# Altium schematic sheet CustumBulun.Sch
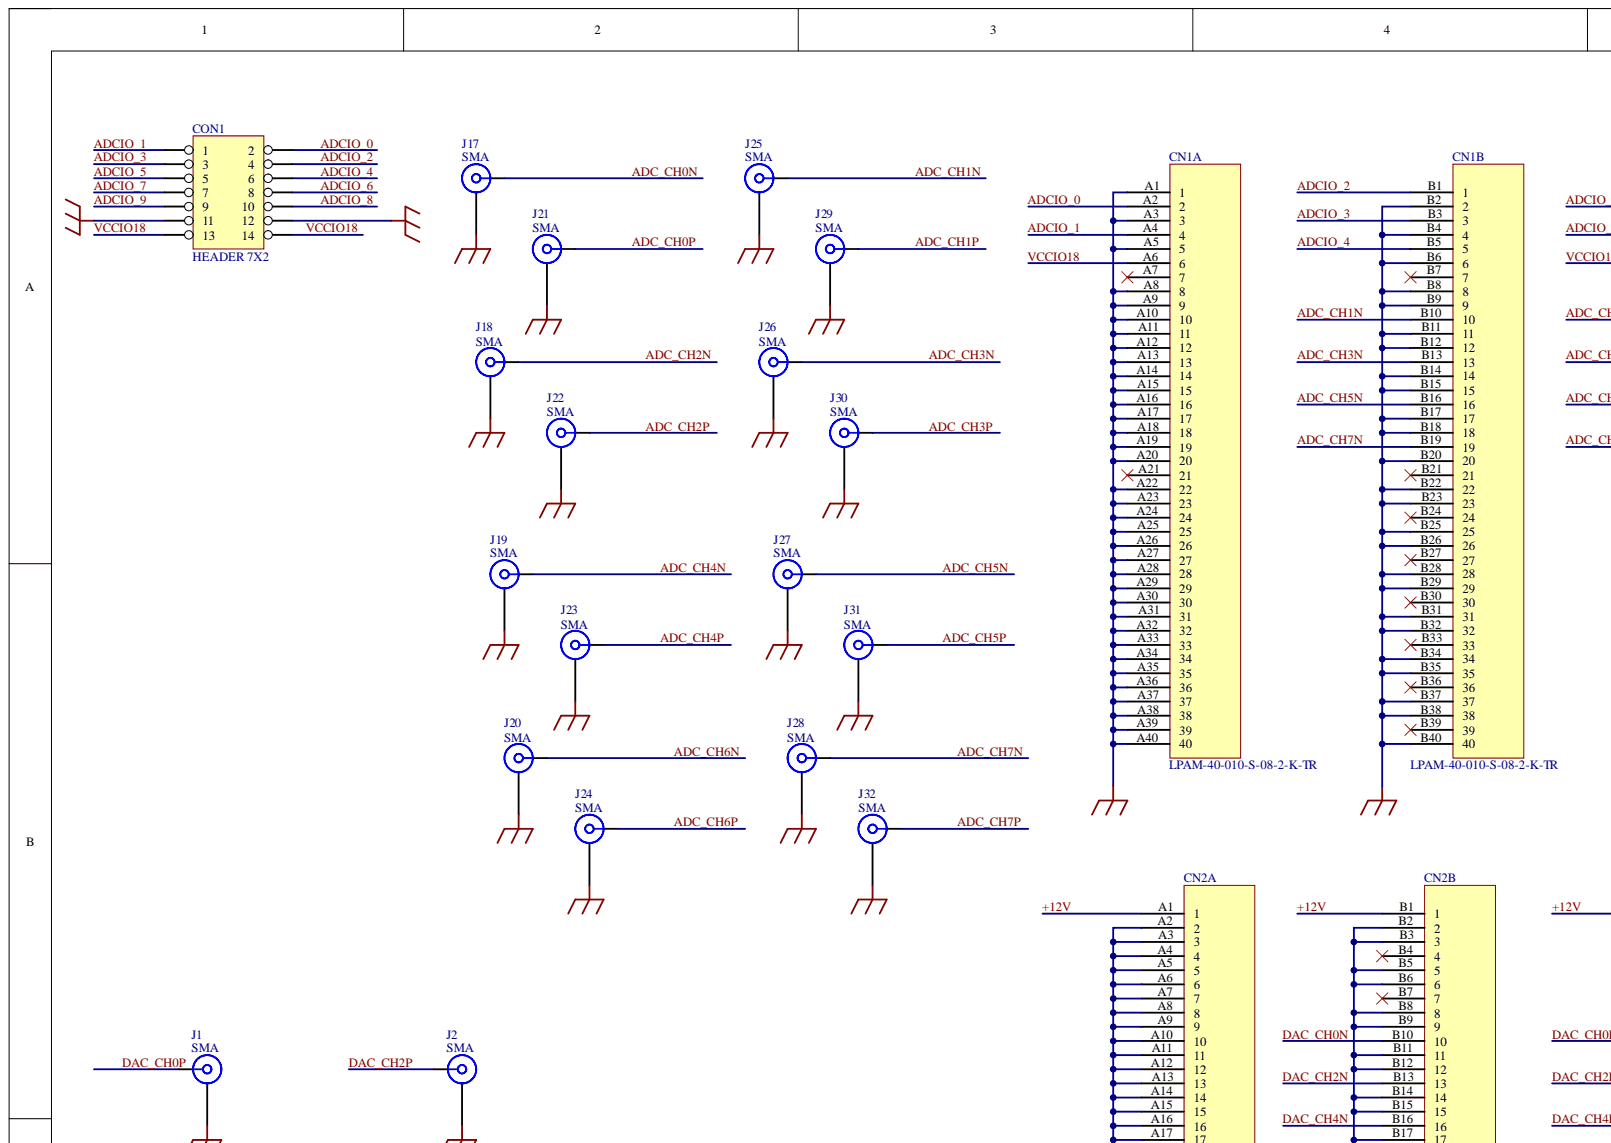
[diagram p0: CustumBulun.Sch, top left]
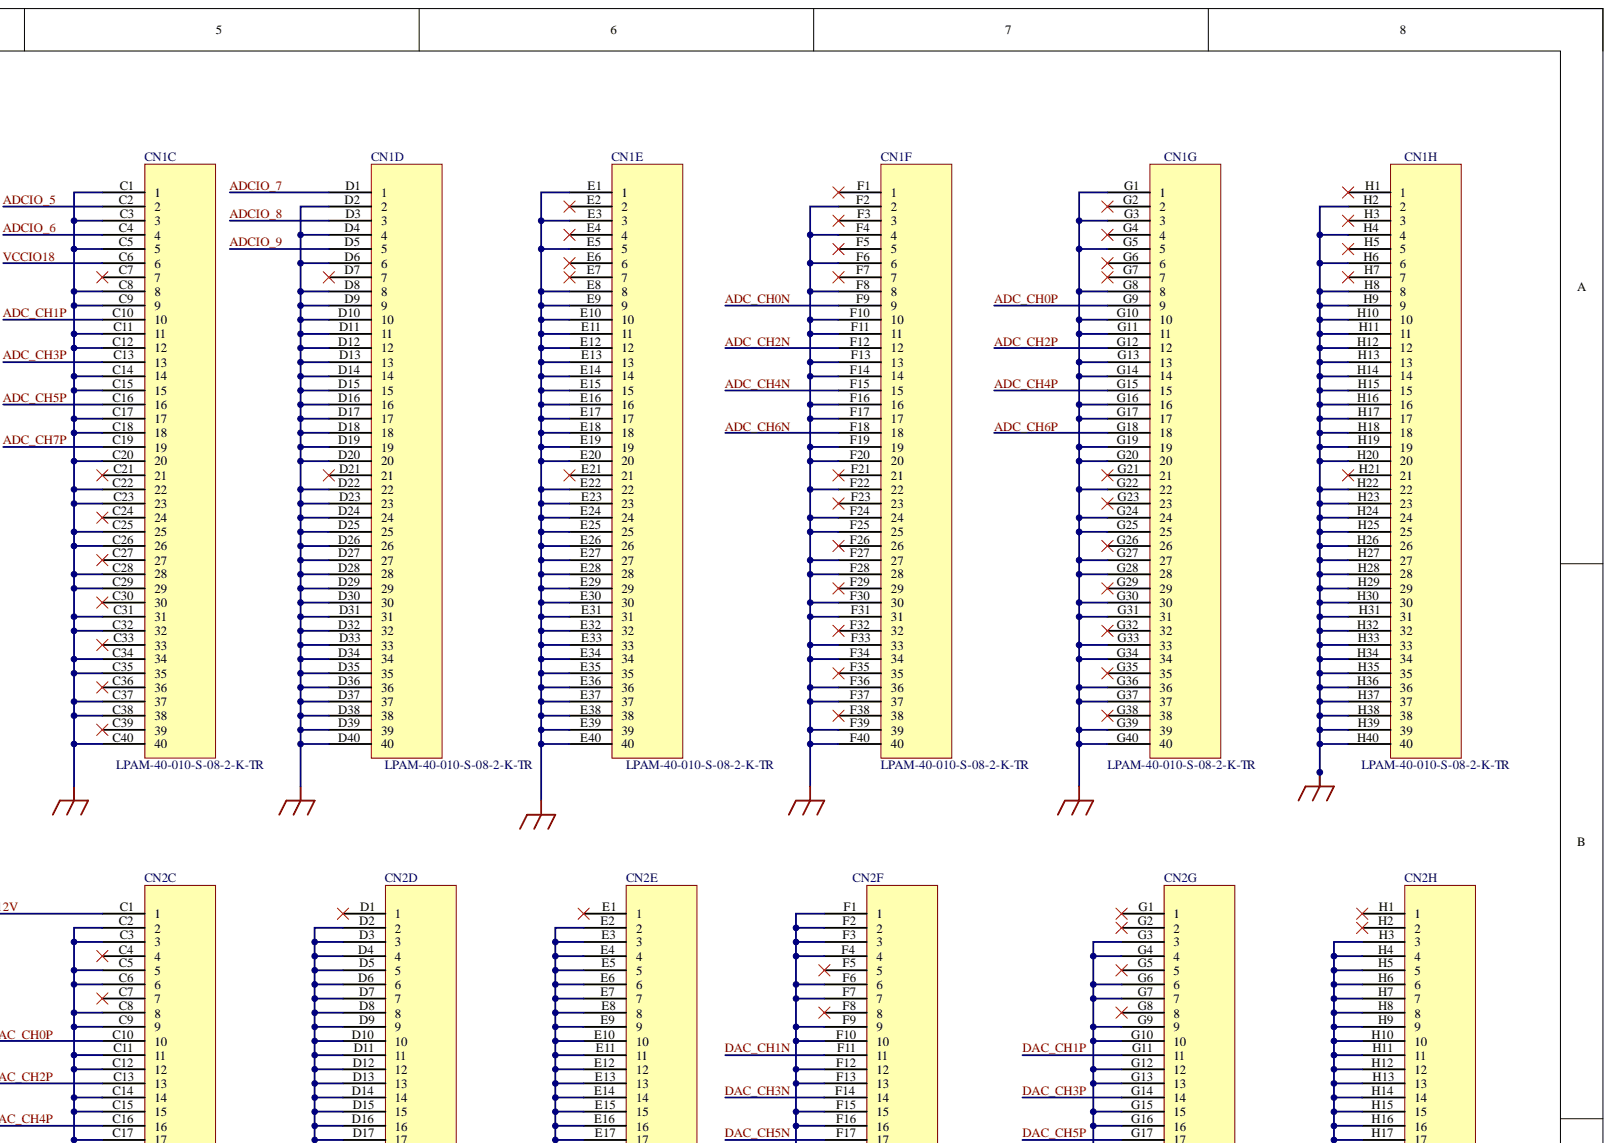
[diagram p1: CustumBulun.Sch, top right]
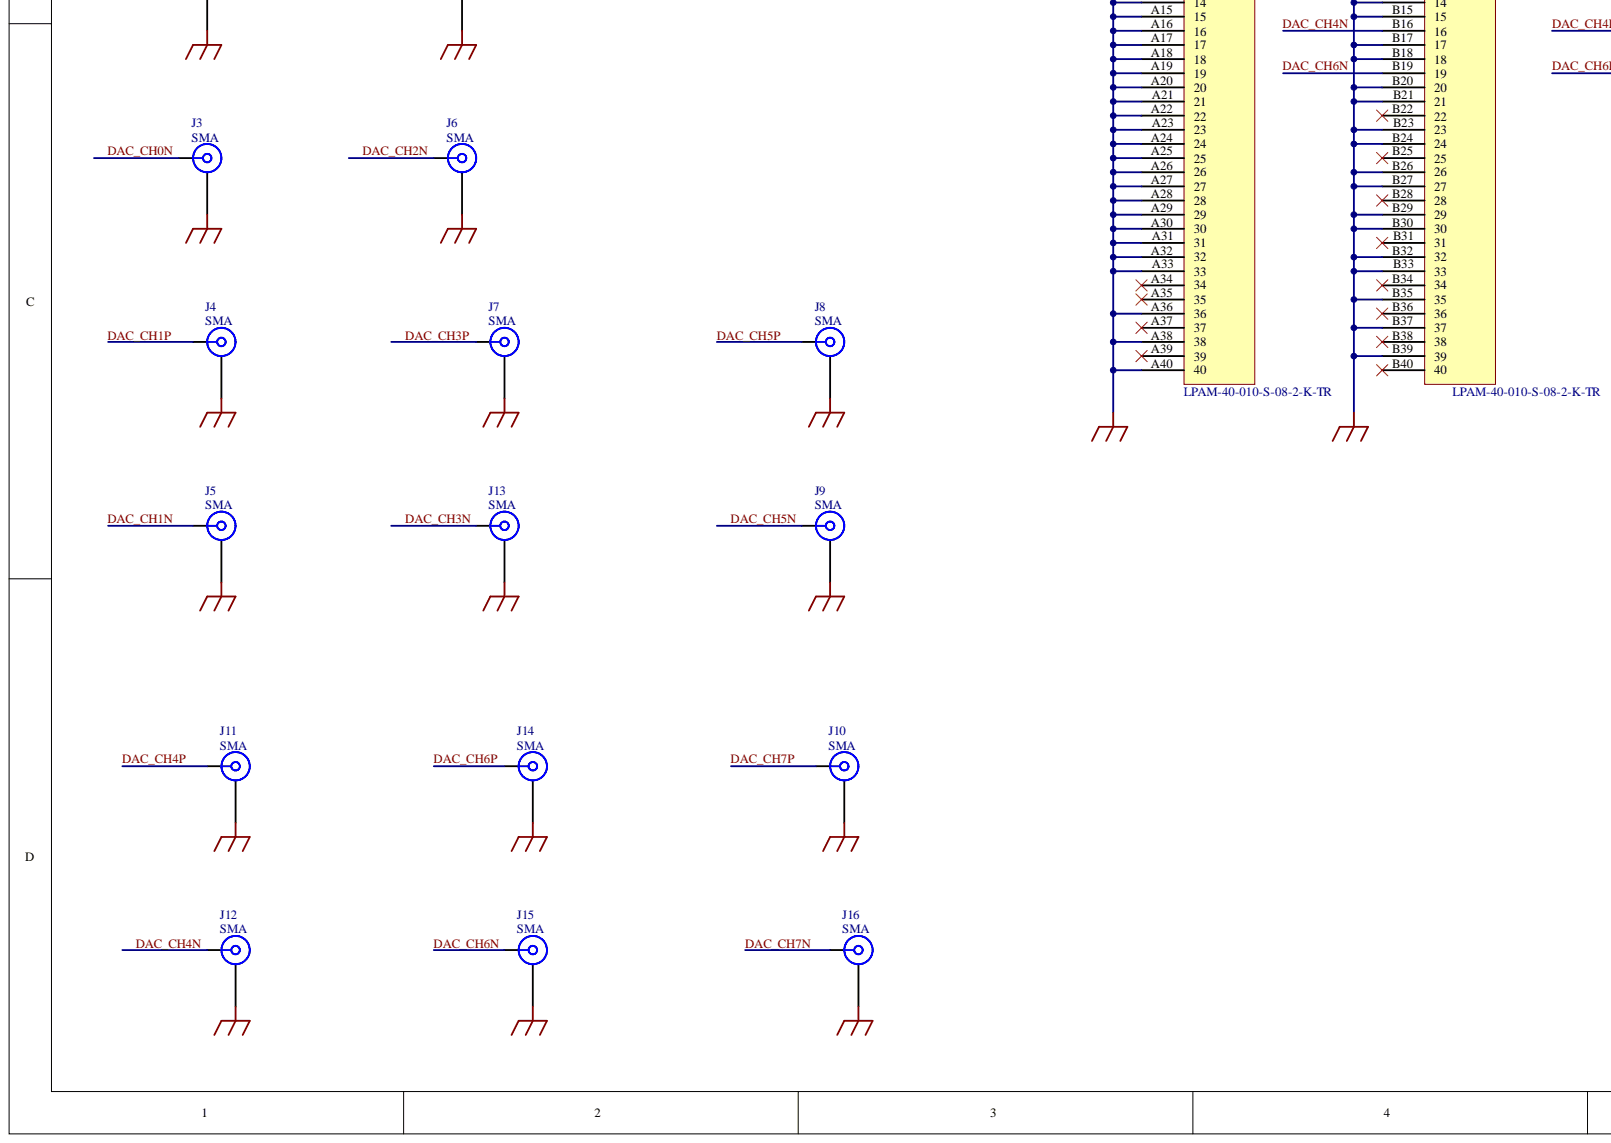
[diagram p2: CustumBulun.Sch, bottom left]
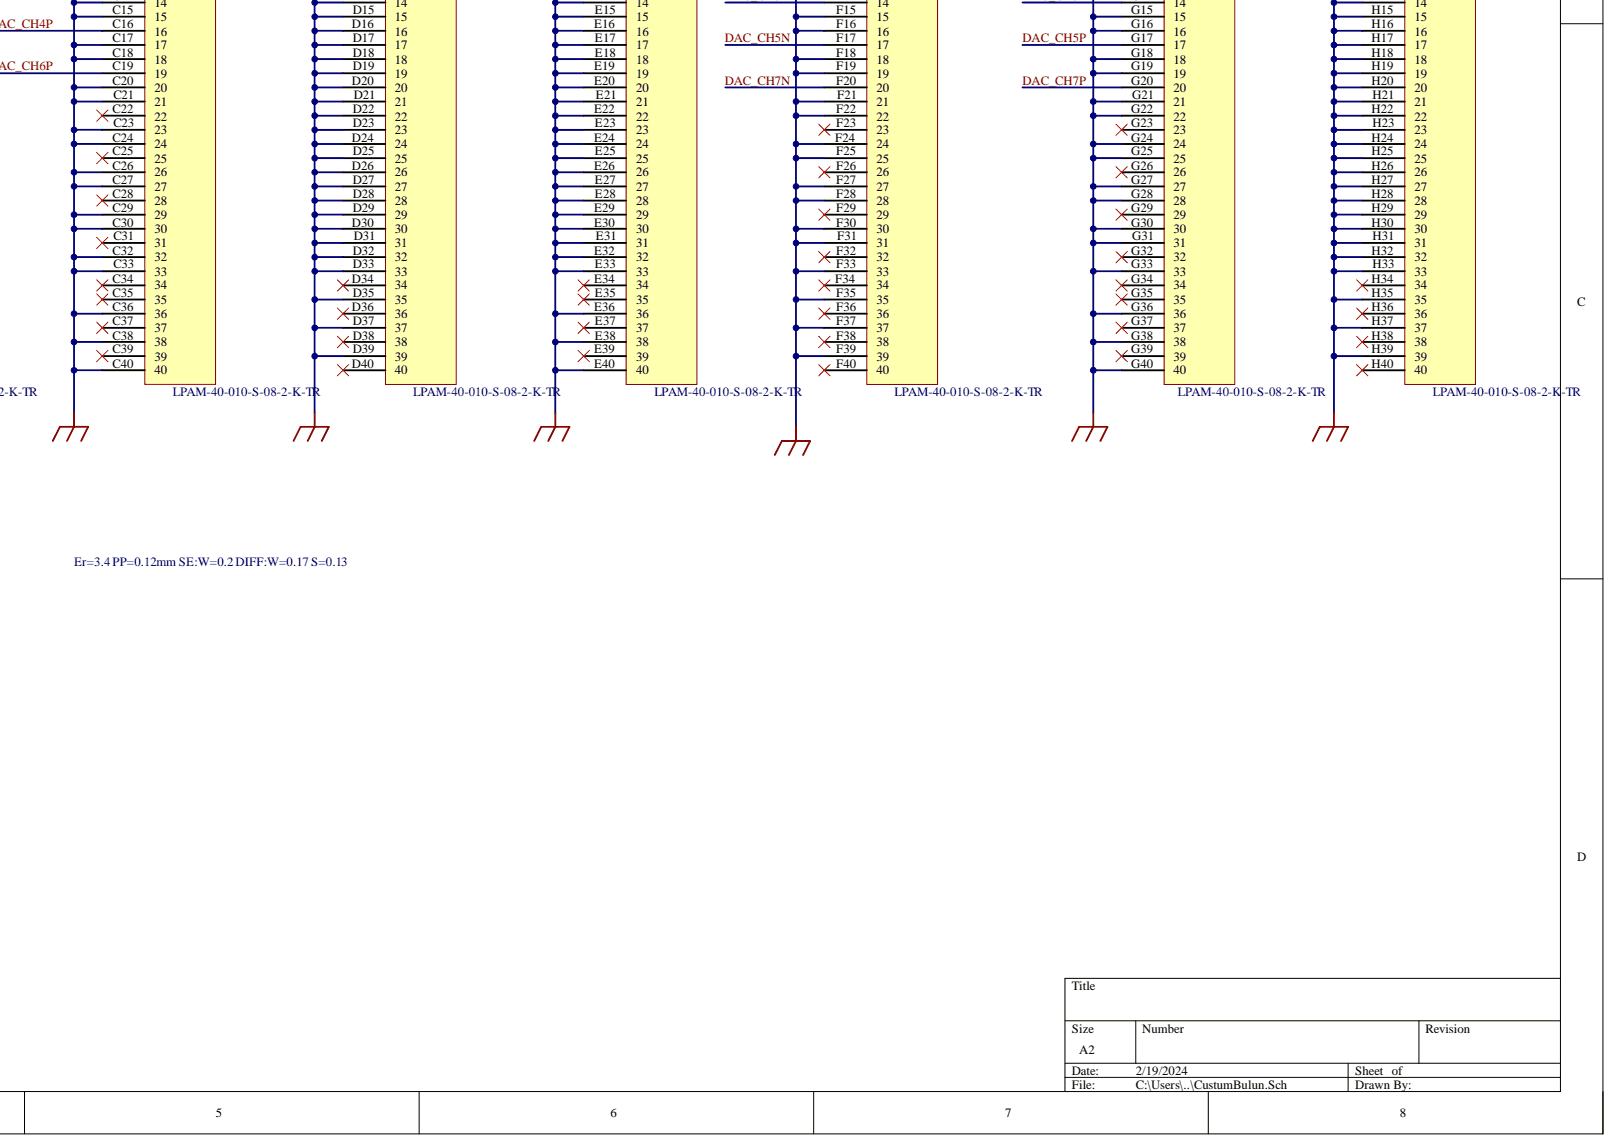
[diagram p3: CustumBulun.Sch, bottom right]

## Parts
CN1  LPAM-40-010-S-08-2-K-TR  [LPAM-40-010-S-08-2-K-TR]  (parts A,B,C,D,E,F,G,H)
CN2  LPAM-40-010-S-08-2-K-TR  [LPAM-40-010-S-08-2-K-TR]  (parts A,B,C,D,E,F,G,H)
CON1  HEADER 7X2  [CON14]
J1  SMA  [SMA]
J2  SMA  [SMA]
J3  SMA  [SMA]
J4  SMA  [SMA]
J5  SMA  [SMA]
J6  SMA  [SMA]
J7  SMA  [SMA]
J8  SMA  [SMA]
J9  SMA  [SMA]
J10  SMA  [SMA]
J11  SMA  [SMA]
J12  SMA  [SMA]
J13  SMA  [SMA]
J14  SMA  [SMA]
J15  SMA  [SMA]
J16  SMA  [SMA]
J17  SMA  [SMA]
J18  SMA  [SMA]
J19  SMA  [SMA]
J20  SMA  [SMA]
J21  SMA  [SMA]
J22  SMA  [SMA]
J23  SMA  [SMA]
J24  SMA  [SMA]
J25  SMA  [SMA]
J26  SMA  [SMA]
J27  SMA  [SMA]
J28  SMA  [SMA]
J29  SMA  [SMA]
J30  SMA  [SMA]
J31  SMA  [SMA]
J32  SMA  [SMA]

## Nets
GND: CN1.A1 CN1.A3 CN1.A5 CN1.A8 CN1.A9 CN1.A10 CN1.A11 CN1.A12 CN1.A13 CN1.A14 CN1.A15 CN1.A16 CN1.A17 CN1.A18 CN1.A19 CN1.A20 CN1.A22 CN1.A23 CN1.A24 CN1.A25 CN1.A26 CN1.A27 CN1.A28 CN1.A29 CN1.A30 CN1.A31 CN1.A32 CN1.A33 CN1.A34 CN1.A35 CN1.A36 CN1.A37 CN1.A38 CN1.A39 CN1.A40 CN1.B2 CN1.B4 CN1.B6 CN1.B8 CN1.B9 CN1.B11 CN1.B12 CN1.B14 CN1.B15 CN1.B17 CN1.B18 CN1.B20 CN1.B22 CN1.B23 CN1.B25 CN1.B26 CN1.B28 CN1.B29 CN1.B31 CN1.B32 CN1.B34 CN1.B35 CN1.B37 CN1.B38 CN1.B40 CN1.C1 CN1.C3 CN1.C5 CN1.C8 CN1.C9 CN1.C11 CN1.C12 CN1.C14 CN1.C15 CN1.C17 CN1.C18 CN1.C20 CN1.C22 CN1.C23 CN1.C25 CN1.C26 CN1.C28 CN1.C29 CN1.C31 CN1.C32 CN1.C34 CN1.C35 CN1.C37 CN1.C38 CN1.C40 CN1.D2 CN1.D4 CN1.D6 CN1.D8 CN1.D9 CN1.D10 CN1.D11 CN1.D12 CN1.D13 CN1.D14 CN1.D15 CN1.D16 CN1.D17 CN1.D18 CN1.D19 CN1.D20 CN1.D22 CN1.D23 CN1.D24 CN1.D25 CN1.D26 CN1.D27 CN1.D28 CN1.D29 CN1.D30 CN1.D31 CN1.D32 CN1.D33 CN1.D34 CN1.D35 CN1.D36 CN1.D37 CN1.D38 CN1.D39 CN1.D40 CN1.E1 CN1.E3 CN1.E5 CN1.E8 CN1.E9 CN1.E10 CN1.E11 CN1.E12 CN1.E13 CN1.E14 CN1.E15 CN1.E16 CN1.E17 CN1.E18 CN1.E19 CN1.E20 CN1.E22 CN1.E23 CN1.E24 CN1.E25 CN1.E26 CN1.E27 CN1.E28 CN1.E29 CN1.E30 CN1.E31 CN1.E32 CN1.E33 CN1.E34 CN1.E35 CN1.E36 CN1.E37 CN1.E38 CN1.E39 CN1.E40 CN1.F2 CN1.F4 CN1.F6 CN1.F8 CN1.F10 CN1.F11 CN1.F13 CN1.F14 CN1.F16 CN1.F17 CN1.F19 CN1.F20 CN1.F22 CN1.F24 CN1.F25 CN1.F27 CN1.F28 CN1.F30 CN1.F31 CN1.F33 CN1.F34 CN1.F36 CN1.F37 CN1.F39 CN1.F40 CN1.G1 CN1.G3 CN1.G5 CN1.G8 CN1.G10 CN1.G11 CN1.G13 CN1.G14 CN1.G16 CN1.G17 CN1.G19 CN1.G20 CN1.G22 CN1.G24 CN1.G25 CN1.G27 CN1.G28 CN1.G30 CN1.G31 CN1.G33 CN1.G34 CN1.G36 CN1.G37 CN1.G39 CN1.G40 CN1.H2 CN1.H4 CN1.H6 CN1.H8 CN1.H9 CN1.H10 CN1.H11 CN1.H12 CN1.H13 CN1.H14 CN1.H15 CN1.H16 CN1.H17 CN1.H18 CN1.H19 CN1.H20 CN1.H22 CN1.H23 CN1.H24 CN1.H25 CN1.H26 CN1.H27 CN1.H28 CN1.H29 CN1.H30 CN1.H31 CN1.H32 CN1.H33 CN1.H34 CN1.H35 CN1.H36 CN1.H37 CN1.H38 CN1.H39 CN1.H40 CN2.A2 CN2.A3 CN2.A4 CN2.A5 CN2.A6 CN2.A7 CN2.A8 CN2.A9 CN2.A10 CN2.A11 CN2.A12 CN2.A13 CN2.A14 CN2.A15 CN2.A16 CN2.A17 CN2.A18 CN2.A19 CN2.A20 CN2.A21 CN2.A22 CN2.A23 CN2.A24 CN2.A25 CN2.A26 CN2.A27 CN2.A28 CN2.A29 CN2.A30 CN2.A31 CN2.A32 CN2.A33 CN2.A36 CN2.A38 CN2.A40 CN2.B2 CN2.B3 CN2.B5 CN2.B6 CN2.B8 CN2.B9 CN2.B11 CN2.B12 CN2.B14 CN2.B15 CN2.B17 CN2.B18 CN2.B20 CN2.B21 CN2.B23 CN2.B24 CN2.B26 CN2.B27 CN2.B29 CN2.B30 CN2.B32 CN2.B33 CN2.B35 CN2.B37 CN2.B39 CN2.C2 CN2.C3 CN2.C5 CN2.C6 CN2.C8 CN2.C9 CN2.C11 CN2.C12 CN2.C14 CN2.C15 CN2.C17 CN2.C18 CN2.C20 CN2.C21 CN2.C23 CN2.C24 CN2.C26 CN2.C27 CN2.C29 CN2.C30 CN2.C32 CN2.C33 CN2.C36 CN2.C38 CN2.C40 CN2.D2 CN2.D3 CN2.D4 CN2.D5 CN2.D6 CN2.D7 CN2.D8 CN2.D9 CN2.D10 CN2.D11 CN2.D12 CN2.D13 CN2.D14 CN2.D15 CN2.D16 CN2.D17 CN2.D18 CN2.D19 CN2.D20 CN2.D21 CN2.D22 CN2.D23 CN2.D24 CN2.D25 CN2.D26 CN2.D27 CN2.D28 CN2.D29 CN2.D30 CN2.D31 CN2.D32 CN2.D33 CN2.D35 CN2.D37 CN2.D39 CN2.E2 CN2.E3 CN2.E4 CN2.E5 CN2.E6 CN2.E7 CN2.E8 CN2.E9 CN2.E10 CN2.E11 CN2.E12 CN2.E13 CN2.E14 CN2.E15 CN2.E16 CN2.E17 CN2.E18 CN2.E19 CN2.E20 CN2.E21 CN2.E22 CN2.E23 CN2.E24 CN2.E25 CN2.E26 CN2.E27 CN2.E28 CN2.E29 CN2.E30 CN2.E31 CN2.E32 CN2.E33 CN2.E36 CN2.E38 CN2.E40 CN2.F1 CN2.F2 CN2.F3 CN2.F4 CN2.F6 CN2.F7 CN2.F9 CN2.F10 CN2.F12 CN2.F13 CN2.F15 CN2.F16 CN2.F18 CN2.F19 CN2.F21 CN2.F22 CN2.F24 CN2.F25 CN2.F27 CN2.F28 CN2.F30 CN2.F31 CN2.F33 CN2.F35 CN2.F37 CN2.F39 CN2.G3 CN2.G4 CN2.G6 CN2.G7 CN2.G9 CN2.G10 CN2.G12 CN2.G13 CN2.G15 CN2.G16 CN2.G18 CN2.G19 CN2.G21 CN2.G22 CN2.G24 CN2.G25 CN2.G27 CN2.G28 CN2.G30 CN2.G31 CN2.G33 CN2.G36 CN2.G38 CN2.G40 CN2.H3 CN2.H4 CN2.H5 CN2.H6 CN2.H7 CN2.H8 CN2.H9 CN2.H10 CN2.H11 CN2.H12 CN2.H13 CN2.H14 CN2.H15 CN2.H16 CN2.H17 CN2.H18 CN2.H19 CN2.H20 CN2.H21 CN2.H22 CN2.H23 CN2.H24 CN2.H25 CN2.H26 CN2.H27 CN2.H28 CN2.H29 CN2.H30 CN2.H31 CN2.H32 CN2.H33 CN2.H35 CN2.H37 CN2.H39 CON1.11 CON1.12 J1.SHIELD J2.SHIELD J3.SHIELD J4.SHIELD J5.SHIELD J6.SHIELD J7.SHIELD J8.SHIELD J9.SHIELD J10.SHIELD J11.SHIELD J12.SHIELD J13.SHIELD J14.SHIELD J15.SHIELD J16.SHIELD J17.SHIELD J18.SHIELD J19.SHIELD J20.SHIELD J21.SHIELD J22.SHIELD J23.SHIELD J24.SHIELD J25.SHIELD J26.SHIELD J27.SHIELD J28.SHIELD J29.SHIELD J30.SHIELD J31.SHIELD J32.SHIELD
+12V: CN2.A1 CN2.B1 CN2.C1
ADC_CH0N: CN1.F9 J17.CENTER
ADC_CH0P: CN1.G9 J21.CENTER
ADC_CH1N: CN1.B10 J25.CENTER
ADC_CH1P: CN1.C10 J29.CENTER
ADC_CH2N: CN1.F12 J18.CENTER
ADC_CH2P: CN1.G12 J22.CENTER
ADC_CH3N: CN1.B13 J26.CENTER
ADC_CH3P: CN1.C13 J30.CENTER
ADC_CH4N: CN1.F15 J19.CENTER
ADC_CH4P: CN1.G15 J23.CENTER
ADC_CH5N: CN1.B16 J27.CENTER
ADC_CH5P: CN1.C16 J31.CENTER
ADC_CH6N: CN1.F18 J20.CENTER
ADC_CH6P: CN1.G18 J24.CENTER
ADC_CH7N: CN1.B19 J28.CENTER
ADC_CH7P: CN1.C19 J32.CENTER
ADCIO_0: CN1.A2 CON1.2
ADCIO_1: CN1.A4 CON1.1
ADCIO_2: CN1.B1 CON1.4
ADCIO_3: CN1.B3 CON1.3
ADCIO_4: CN1.B5 CON1.6
ADCIO_5: CN1.C2 CON1.5
ADCIO_6: CN1.C4 CON1.8
ADCIO_7: CN1.D1 CON1.7
ADCIO_8: CN1.D3 CON1.10
ADCIO_9: CN1.D5 CON1.9
DAC_CH0N: CN2.B10 J3.CENTER
DAC_CH0P: CN2.C10 J1.CENTER
DAC_CH1N: CN2.F11 J5.CENTER
DAC_CH1P: CN2.G11 J4.CENTER
DAC_CH2N: CN2.B13 J6.CENTER
DAC_CH2P: CN2.C13 J2.CENTER
DAC_CH3N: CN2.F14 J13.CENTER
DAC_CH3P: CN2.G14 J7.CENTER
DAC_CH4N: CN2.B16 J12.CENTER
DAC_CH4P: CN2.C16 J11.CENTER
DAC_CH5N: CN2.F17 J9.CENTER
DAC_CH5P: CN2.G17 J8.CENTER
DAC_CH6N: CN2.B19 J15.CENTER
DAC_CH6P: CN2.C19 J14.CENTER
DAC_CH7N: CN2.F20 J16.CENTER
DAC_CH7P: CN2.G20 J10.CENTER
VCCIO18: CN1.A6 CN1.C6 CON1.13 CON1.14

Not connected: CN1 A7 A21 B7 B21 B24 B27 B30 B33 B36 B39 C7 C21 C24 C27 C30 C33 C36 C39 D7 D21 E2 E4 E6 E7 E21 F1 F3 F5 F7 F21 F23 F26 F29 F32 F35 F38 G2 G4 G6 G7 G21 G23 G26 G29 G32 G35 G38 H1 H3 H5 H7 H21; CN2 A34 A35 A37 A39 B4 B7 B22 B25 B28 B31 B34 B36 B38 B40 C4 C7 C22 C25 C28 C31 C34 C35 C37 C39 D1 D34 D36 D38 D40 E1 E34 E35 E37 E39 F5 F8 F23 F26 F29 F32 F34 F36 F38 F40 G1 G2 G5 G8 G23 G26 G29 G32 G34 G35 G37 G39 H1 H2 H34 H36 H38 H40

## Library data of the parts
J1-J32 = BNC: Component Kind: Standard
CN1 CN2 = LPAM-40-010-S-08-2-K-TR: Component Kind: Standard
CON1 = HEADER 7X2: Component Kind: Standard
